# Altium schematic sheet EncoderI2C.SchDoc(EncoderI2C)
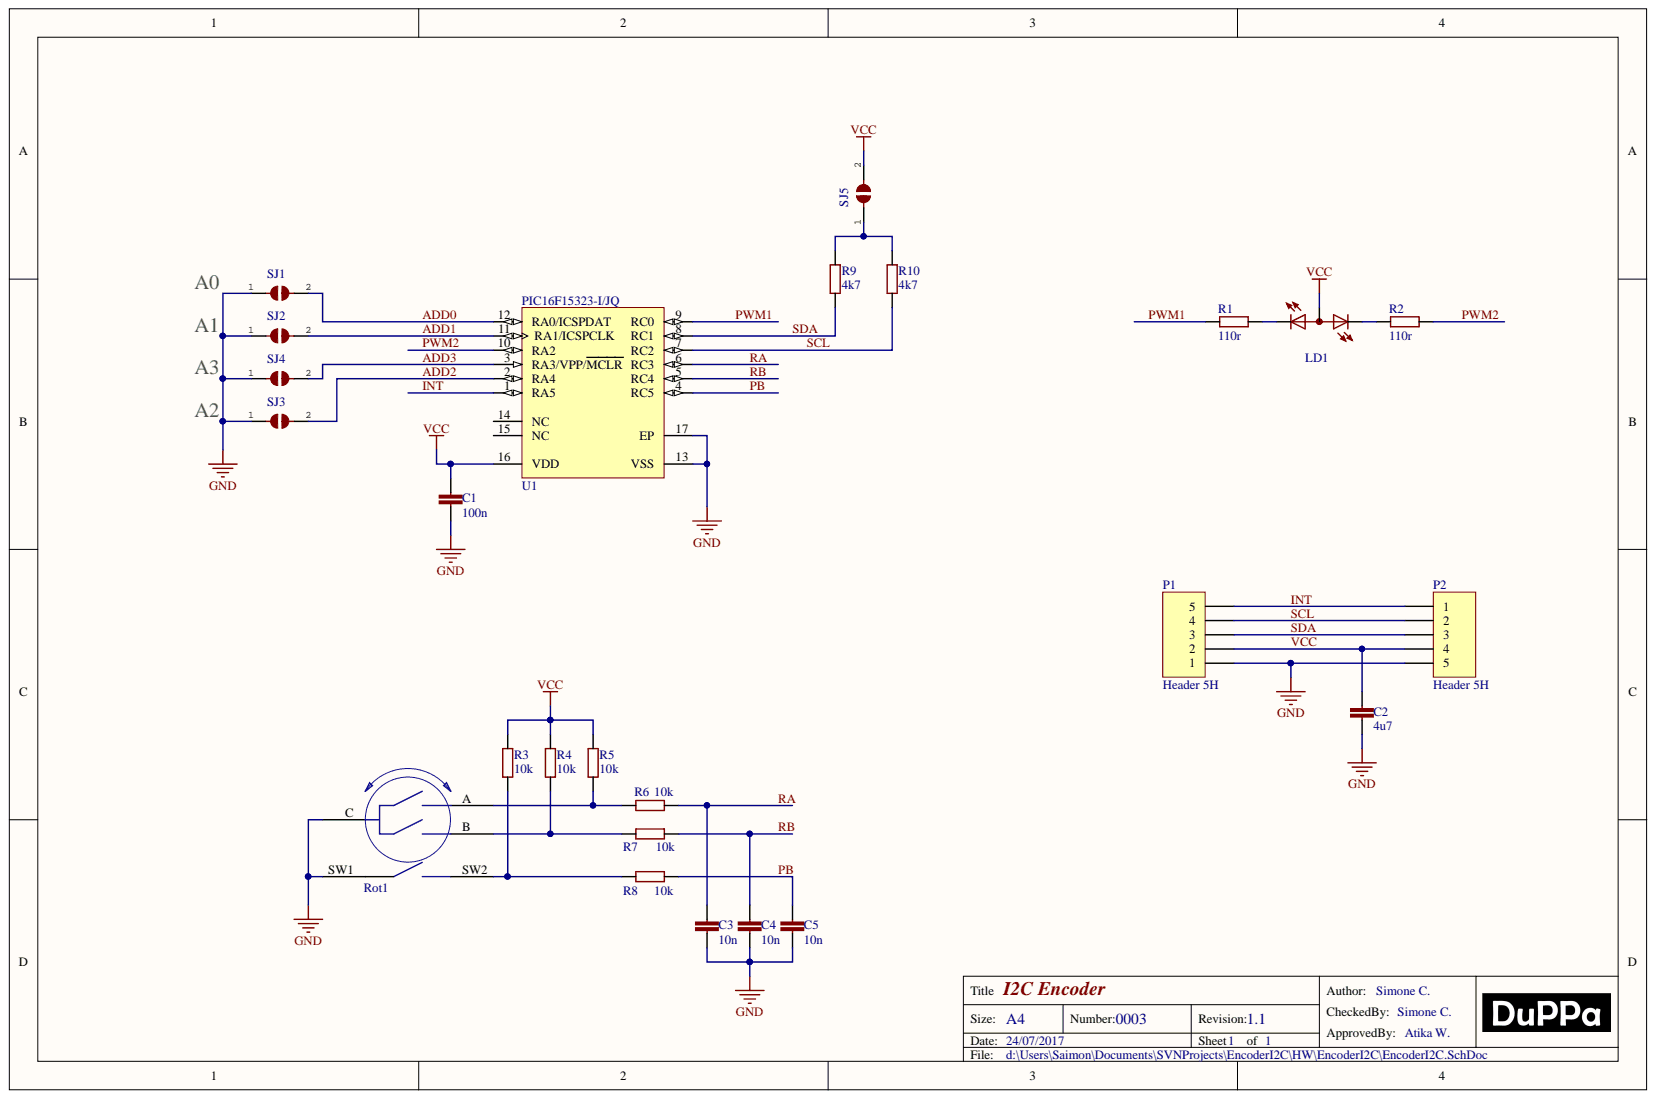
[diagram p0: EncoderI2C.SchDoc]

## Parts
C1  value 100n  [C0402]  (hidden comment: C-EUC0402)
C2  value 4u7  [C0603]  (hidden comment: C-EUC0603)
C3  value 10n  [C0402]  (hidden comment: C-EUC0402)
C4  value 10n  [C0402]  (hidden comment: C-EUC0402)
C5  value 10n  [C0402]  (hidden comment: C-EUC0402)
LD1  DUOLED-RG-A  [DUOLED-A-5MM]  (value not drawn)
P1  Header 5H  [HDR1X5H]
P2  Header 5H  [HDR1X5H]
R1  value 110r  [R0402]  (hidden comment: R-EU_R0402)
R2  value 110r  [R0402]  (hidden comment: R-EU_R0402)
R3  value 10k  [R0402]  (hidden comment: R-EU_R0402)
R4  value 10k  [R0402]  (hidden comment: R-EU_R0402)
R5  value 10k  [R0402]  (hidden comment: R-EU_R0402)
R6  value 10k  [R0402]  (hidden comment: R-EU_R0402)
R7  value 10k  [R0402]  (hidden comment: R-EU_R0402)
R8  value 10k  [R0402]  (hidden comment: R-EU_R0402)
R9  value 4k7  [R0402]  (hidden comment: R-EU_R0402)
R10  value 4k7  [R0402]  (hidden comment: R-EU_R0402)
Rot1  RotaryEncoderPB  [RotaryEncoder]  (value not drawn)
SJ1  SJ  [SJ]
SJ2  SJ  [SJ]
SJ3  SJ  [SJ]
SJ4  SJ  [SJ]
SJ5  SJ  [SJ]
U1  PIC16F15323-I/JQ  [MCHP-QFN-ML16_L]

## Nets
GND: C1.2 C2.2 C3.2 C4.2 C5.2 P1.1 P2.5 Rot1.C Rot1.SW1 SJ1.1 SJ2.1 SJ3.1 SJ4.1 U1.13(VSS) U1.17(EP)
ADD0: SJ1.2 U1.12(RA0/ICSPDAT)
ADD1: SJ2.2 U1.11(RA1/ICSPCLK)
ADD2: SJ3.2 U1.2(RA4)
ADD3: SJ4.2 U1.3(RA3/VPP/MCLR)
INT: P1.5 P2.1 U1.1(RA5)
PB: C5.1 R8.2 U1.4(RC5)
PWM1: R1.2 U1.9(RC0)
PWM2: R2.1 U1.10(RA2)
RA: C3.1 R6.2 U1.6(RC3)
RB: C4.1 R7.2 U1.5(RC4)
SCL: P1.4 P2.2 R10.1 U1.7(RC2)
SDA: P1.3 P2.3 R9.1 U1.8(RC1)
VCC: C1.1 C2.1 LD1.A P1.2 P2.4 R3.2 R4.2 R5.2 SJ5.2 U1.16(VDD)
NetLD1_CR: LD1.CR R2.2
NetLD1_CX: LD1.CX R1.1
NetR3_1: R3.1 R8.1 Rot1.SW2
NetR4_1: R4.1 R7.1 Rot1.B
NetR5_1: R5.1 R6.1 Rot1.A
NetR9_2: R9.2 R10.2 SJ5.1

Not connected: U1 14(NC) 15(NC)

## Library data of the parts
C1 = C-EUC0402: Capacitor; PartNumber: GRM155R61C104KA88D; MouserCode: 81-GRM155R61C104KA8D; Library Name: rcl.IntLib; Component Kind: Standard
C2 = C-EUC0603: Capacitor; PartNumber: CC0603KRX5R7BB475; MouserCode: 603-CC603KRX5R7BB475; Library Name: rcl.IntLib; Component Kind: Standard
C3-C5 = C-EUC0402: Capacitor; PartNumber: GRM155R71H103KA88D; MouserCode: 81-GRM155R71H103KA8D; Library Name: rcl.IntLib; Component Kind: Standard
LD1 = DUOLED-RG-A: Common Anode bi-color LED; Library Name: led.IntLib; Component Kind: Standard
P1 = Header 5H: Header, 5-Pin, Right Angle Male; Signal Integrity: Connector; PartNumber: 22-28-8050; MouserCode: 538-22-28-8050; Library Name: Miscellaneous Connectors.IntLib; Component Kind: Standard
P2 = Header 5H: Header, 5-Pin, Right Angle Female; PartNumber: BG302-05-A-L-G; Signal Integrity: Connector; Library Name: Miscellaneous Connectors.IntLib; FarnellCode: 2084275; Component Kind: Standard
R1 R2 = R-EU_R0402: Resistor; PartNumber: ERJ-2GEJ111X; MouserCode: 667-ERJ-2GEJ111X; Library Name: rcl.IntLib; Component Kind: Standard
R3-R8 = R-EU_R0402: Resistor; PartNumber: RC0402FR-0710KL; MouserCode: 603-RC0402FR-0710KL; Library Name: rcl.IntLib; Component Kind: Standard
R9 R10 = R-EU_R0402: Resistor; PartNumber: ERJ-2GEJ4R7X; MouserCode: 667-ERJ-2GEJ4R7X; Library Name: rcl.IntLib; Component Kind: Standard
Rot1 = RotaryEncoderPB: Rotary Encoder; Library Name: Miscellaneous Devices.IntLib; Component Kind: Standard
SJ1-SJ5 = SJ: SMD Jumper; Library Name: jumper.IntLib; Component Kind: Standard
U1 = PIC16F15323-I/JQ: Flash, 8-Bit Microcontroller, 20 MHz, 11 I/O, -40 to 125 degC, 16-pin QFN (MG16), Tube; PartNumber: PIC16F15323-I/JQ; MouserCode: 579-PIC16F15323-I/JQ; Library Name: Microchip PIC16 Part 1.IntLib; Component Kind: Standard
